# Altium schematic sheet 02. MCU.SchDoc
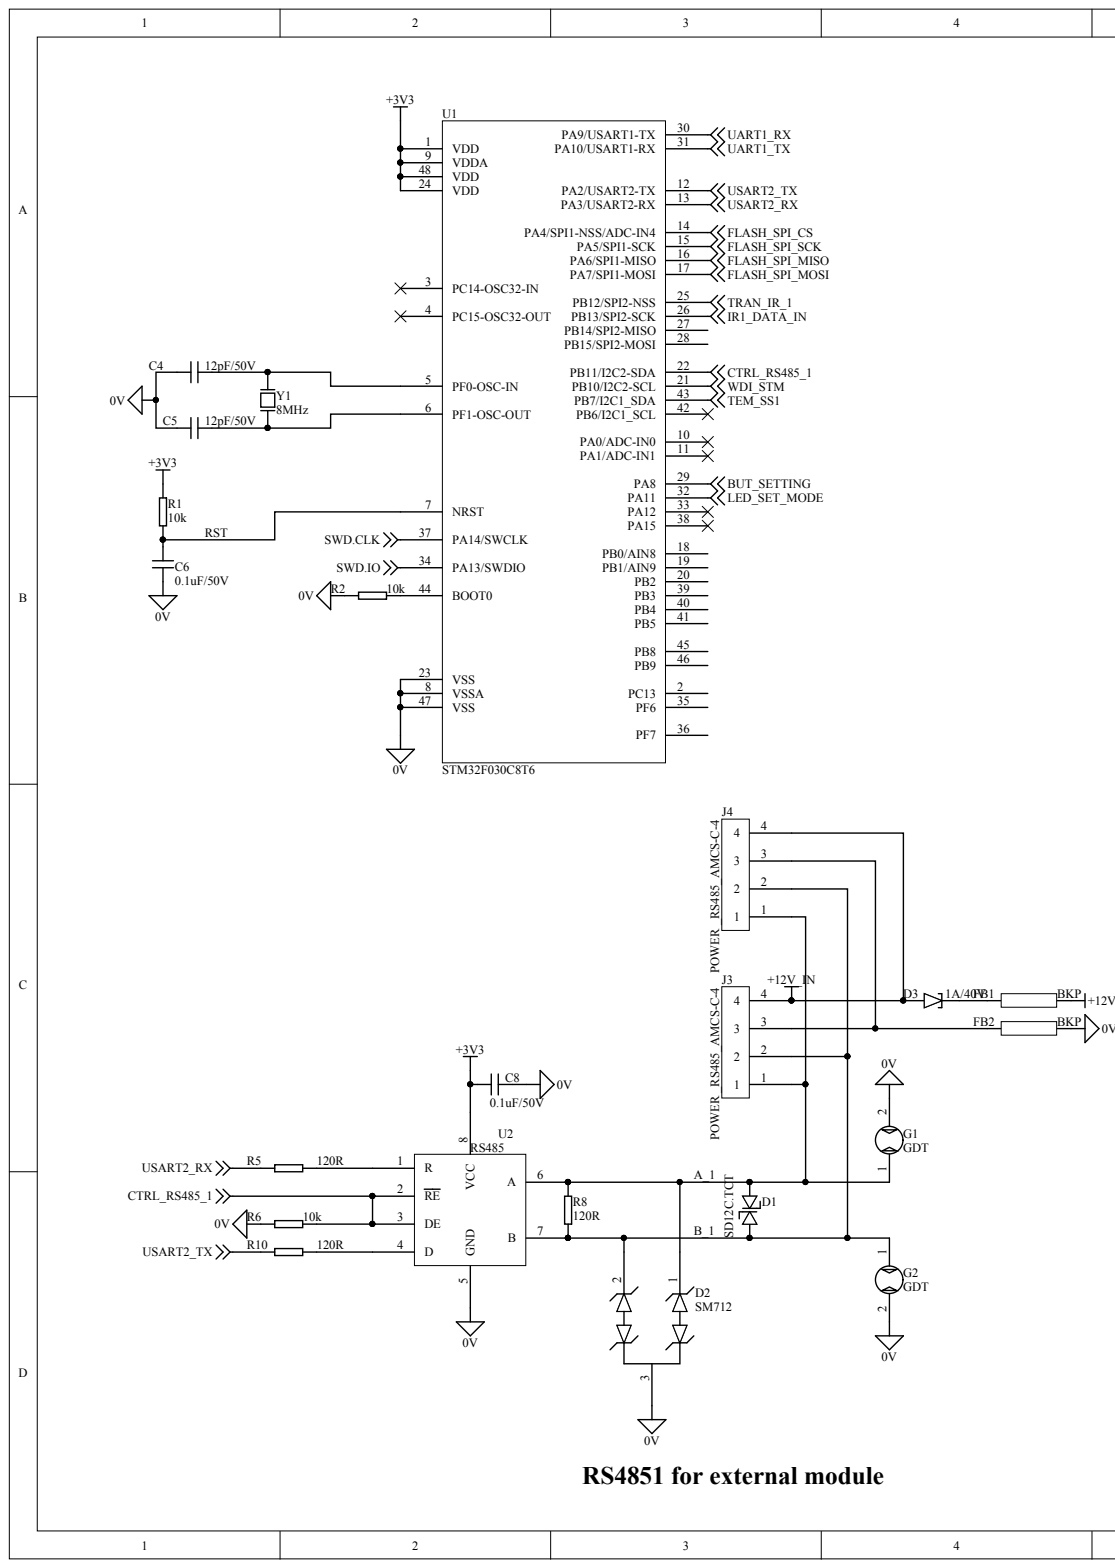
[diagram p0: 02. MCU.SchDoc, left]
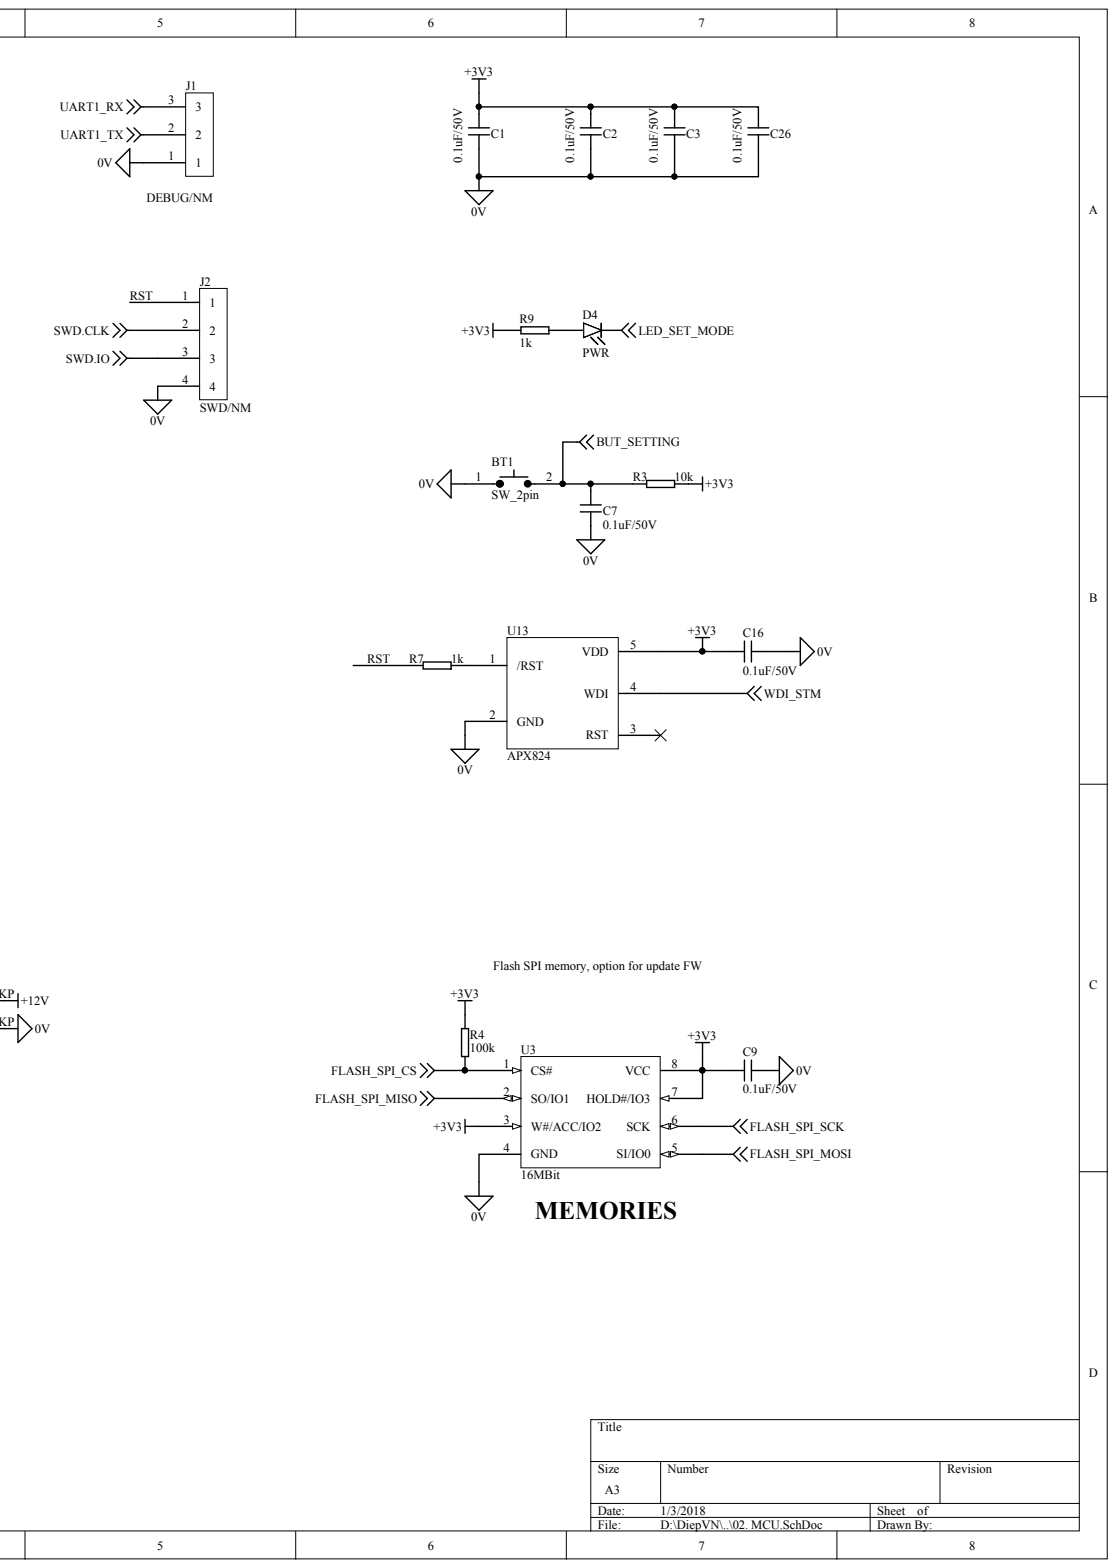
[diagram p1: 02. MCU.SchDoc, right]

## Parts
BT1  SW_2pin  [BUTT_RA]
C1  0.1uF/50V  [0805]
C2  0.1uF/50V  [0805]
C3  0.1uF/50V  [0805]
C4  12pF/50V  [0805]
C5  12pF/50V  [0805]
C6  0.1uF/50V  [0805]
C7  0.1uF/50V  [0805]
C8  0.1uF/50V  [0805]
C9  0.1uF/50V  [0805]
C16  0.1uF/50V  [0805]
C26  0.1uF/50V  [0805]
D1  SD12C.TCT  [SOD-323]
D2  SM712  [SOT-23]
D3  1A/40V  [SMA]
D4  PWR  [0805_Pol]
FB1  BKP  [0805]
FB2  BKP  [0805]
G1  GDT  [1812]
G2  GDT  [1812]
J1  DEBUG/NM  [Header 3x1_2.54mm]
J2  SWD/NM  [Header_4_2m54]
J3  POWER _RS485_AMCS-C-4  [CONN 4x1 3.81MM]
J4  POWER _RS485_AMCS-C-4  [CONN 4x1 3.81MM]
R1  10k  [0805]
R2  10k  [0805]
R3  10k  [0805]
R4  100k  [0805]
R5  120R  [0805]
R6  10k  [0805]
R7  1k  [0805]
R8  120R  [0805]
R9  1k  [0805]
R10  120R  [0805]
U1  STM32F030C8T6  [LQFP-48_7mx7mx1m6]
U2  RS485  [SOIC-8]
U3  16MBit  [SOIC-8]
U13  APX824  [SOT23_5]
Y1  8MHz  [2-SMD]

## Nets
0V: BT1.1 C1.2 C2.2 C3.2 C4.1 C5.1 C6.2 C7.1 C8.2 C9.1 C16.1 C26.2 D2.3 FB2.2 G1.2 G2.2 J1.1 J2.4 R2.2 R6.1 U1.8(VSSA) U1.23(VSS) U1.47(VSS) U2.5(GND) U3.4(GND) U13.2(GND)
+3V3: C1.1 C2.1 C3.1 C8.1 C9.2 C16.2 C26.1 R1.1 R3.2 R4.2 R9.2 U1.1(VDD) U1.9(VDDA) U1.24(VDD) U1.48(VDD) U2.8(VCC) U3.3(W#/ACC/IO2) U3.7(HOLD#/IO3) U3.8(VCC) U13.5(VDD)
+12V: FB1.2
+12V_IN: D3.1 J3.4 J4.4
BUT_SETTING: BT1.2 C7.2 R3.1 U1.29(PA8)
CTRL_RS485_1: R6.2 U1.22(PB11/I2C2-SDA) U2.2(RE) U2.3(DE)
FLASH_SPI_CS: R4.1 U1.14(PA4/SPI1-NSS/ADC-IN4) U3.1(CS#)
FLASH_SPI_MISO: U1.16(PA6/SPI1-MISO) U3.2(SO/IO1)
FLASH_SPI_MOSI: U1.17(PA7/SPI1-MOSI) U3.5(SI/IO0)
FLASH_SPI_SCK: U1.15(PA5/SPI1-SCK) U3.6(SCK)
IR1_DATA_IN: U1.26(PB13/SPI2-SCK)
LED_SET_MODE: D4.2 U1.32(PA11)
SWD.CLK: J2.2 U1.37(PA14/SWCLK)
SWD.IO: J2.3 U1.34(PA13/SWDIO)
TEM_SS1: U1.43(PB7/I2C1_SDA)
TRAN_IR_1: U1.25(PB12/SPI2-NSS)
UART1_RX: J1.3 U1.30(PA9/USART1-TX)
UART1_TX: J1.2 U1.31(PA10/USART1-RX)
USART2_RX: R5.1 U1.13(PA3/USART2-RX)
USART2_TX: R10.1 U1.12(PA2/USART2-TX)
WDI_STM: U1.21(PB10/I2C2-SCL) U13.4(WDI)
A_1: D1.2 D2.1 G1.1 J3.1 J4.1 R8.2 U2.6(A)
B_1: D1.1 D2.2 G2.1 J3.2 J4.2 R8.1 U2.7(B)
RST: C6.1 J2.1 R1.2 R7.2 U1.7(NRST)
NetC4_2: C4.2 U1.5(PF0-OSC-IN) Y1.2
NetC5_2: C5.2 U1.6(PF1-OSC-OUT) Y1.1
NetD3_2: D3.2 FB1.1
NetD4_1: D4.1 R9.1
NetFB2_1: FB2.1 J3.3 J4.3
NetR2_1: R2.1 U1.44(BOOT0)
NetR5_2: R5.2 U2.1(R)
NetR7_1: R7.1 U13.1(/RST)
NetR10_2: R10.2 U2.4(D)

Not connected: U1 2(PC13) 3(PC14-OSC32-IN) 4(PC15-OSC32-OUT) 10(PA0/ADC-IN0) 11(PA1/ADC-IN1) 18(PB0/AIN8) 19(PB1/AIN9) 20(PB2) 27(PB14/SPI2-MISO) 28(PB15/SPI2-MOSI) 33(PA12) 35(PF6) 36(PF7) 38(PA15) 39(PB3) 40(PB4) 41(PB5) 42(PB6/I2C1_SCL) 45(PB8) 46(PB9); U13 3(RST)

## Library data of the parts
BT1 = SW_2pin: Tactile Switch SPST-NO Side Actuated Through Hole, Right Angle; MFR: Panasonic; Part Number: EVQ-PF006K; Component Kind: Standard; Vender: http://banlinhkien.vn/goods-4789-nut-nhan-2-chan-6x6x5mm-dip-chan-cong-.html; Library Name: Schlib_Diepvn_V01.SchLib
C1-C3 C6-C9 C16 C26 = Cap_0.1uF: CAP CER 0.1UF 50V Y5V 0805; MFR: Yageo; Part Number: CC0805ZRY5V9BB104; ASS: SMT; Component Kind: Standard; Package: 0805; Library Name: Schlib_Diepvn_V01.SchLib
C4 C5 = Cap_12p: CAP CER 12PF 50V NPO 0805; MFR: Yageo; Part Number: CC0805JRNPO9BN120; Component Kind: Standard; Package: 0805; Library Name: Schlib_Diepvn_V01.SchLib
D1 = TVS_RS485: TVS DIODE 12VWM 24VC SOD323; MFR: DIODE Inc; Part Number: D12V0H1U2WS-7; Component Kind: Standard; Package: SC-76, SOD-323; Library Name: Schlib_Diepvn_V01.SchLib
D2 = SM712: TVS DIODE 12VWM 31VC SOT23; MFR: Littelfuse Inc.; Part Number: SM712-02HTG; Component Kind: Standard; Package: SOT23; Library Name: Schlib_Diepvn_V01.SchLib
D3 = DIODE_SHTK_3A: DIODE SCHOTTKY 40V 1A SMA; MFR: DIODE Inc; Part Number: B140-13-F; ASS: SMT; Component Kind: Standard; Package: SMA; Library Name: Schlib_Diepvn_V01.SchLib
D4 = LED_Gr: LED RED CLEAR 0805 SMD; MFR: Lite-On; Part Number: LTST-C171KRKT; Component Kind: Standard; Package: 0805; Library Name: Schlib_Diepvn_V01.SchLib
FB1 FB2 = FERRITBEAD_3.5A: FERRITE BEAD 60 OHM 0805 1LN; MFR: Taiyo Yuden; Part Number: BKP2125HS600-T; ASS: SMT; Component Kind: Standard; Package: 0805; Library Name: Schlib_Diepvn_V01.SchLib
G1 G2 = GDT: GDT 150V 2KA SURFACE MOUNT; MFR: LF; Part Number: SG150; Component Kind: Standard; Package: 1812; Library Name: Schlib_Diepvn_V01.SchLib
J1 = Header 3x1: pitch:2.54; Component Kind: Standard; MFR: https://item.taobao.com/item.htm?spm=a1z10.5-c.w4002-967123376.20.nBOKAF&id=25624752383; Library Name: Schlib_Diepvn_V01.SchLib
J2 = Header 4x1: Pitch:2.54mm; Component Kind: Standard; Library Name: Schlib_Diepvn_V01.SchLib
J3 J4 = Header 4x1: Pitch:2.54mm, 4 POS, BLACK; MFR: TE; Part Number: 284513-4; Component Kind: Standard; Package: Pitch:3.81MM; Library Name: Schlib_Diepvn_V01.SchLib
R1-R3 R6 = Res_10k: RES SMD 10K OHM 1% 1/8W 0805; MFR: Yageo; Part Number: RC0805FR-0710KL; ASS: SMT; BA Code: VT-00575; Component Kind: Standard; Package: 0805; Library Name: Schlib_Diepvn_V01.SchLib
R4 = Res_100k: RES SMD 100K OHM 1% 1/8W 0805; MFR: Yageo; Part Number: RC0805FR-07100KL; ASS: SMT; BA Code: VT-00332; Component Kind: Standard; Package: 0805; Library Name: Schlib_Diepvn_V01.SchLib
R5 R8 R10 = Res_100R: RES SMD 120 OHM 1% 1/8W 0805; MFR: Yageo; Part Number: RC0805FR-07120RL; Component Kind: Standard; Package: 0805; Library Name: Schlib_Diepvn_V01.SchLib
R7 R9 = Res_1k: RES SMD 1K OHM 1% 1/8W 0805; MFR: Yageo; Part Number: RC0805FR-071KL; ASS: SMT; BA Code: VT-00574; Component Kind: Standard; Package: 0805
  R7: Library Name: BA10.v.1.1.0b0.SCHLIB
  R9: Library Name: Schlib_Diepvn_V01.SchLib
U1 = STM32F030C8T6: IC MCU ARM 64K FLASH 48LQFP; MFR: ST; Vender Part: 497-14043-ND; Component Kind: Standard; Package: LQFP-48; Part Number: STM32F030C8T6; Library Name: Schlib_Diepvn_V01.SchLib
U2 = SN65HVD11: IC TXRX RS485 HALF DUPLEX 8SOIC; MFR: Exar Corporation; Part Number: SP3485EN-L; Vender: Digikey; Component Kind: Standard; Package: SOIC-8; Library Name: Schlib_Diepvn_V01.SchLib
U3 = S25FL256SA: IC FLASH 16MBIT 104MHZ 8-SOIC; MFR: GDE; Part Number: GD25Q16CTIGR; ASS: SMT; BA Code: VT-01093; Component Kind: Standard; Package: 8-SOIC (0.154", 3.90mm Width); Library Name: Schlib_Diepvn_V01.SchLib
U13 = TPS3824-33: IC 2.93V SUPPLY MONITOR SOT-23-5; MFR: Diodes Inc; Part Number: APX824-29W5G-7; Component Kind: Standard; Library Name: BA10.v.1.1.0b0.SCHLIB; Package: SOT23-5
Y1 = CRY_8MHZ: CRYSTAL 8.0000MHZ 18PF SMD; MFR: Abracon; Part Number: ABM3-8.000MHZ-D2Y-T; Component Kind: Standard; Package: 2-SMD; Library Name: Schlib_Diepvn_V01.SchLib
